# Altium schematic sheet MooresGlobe r3.SchDoc
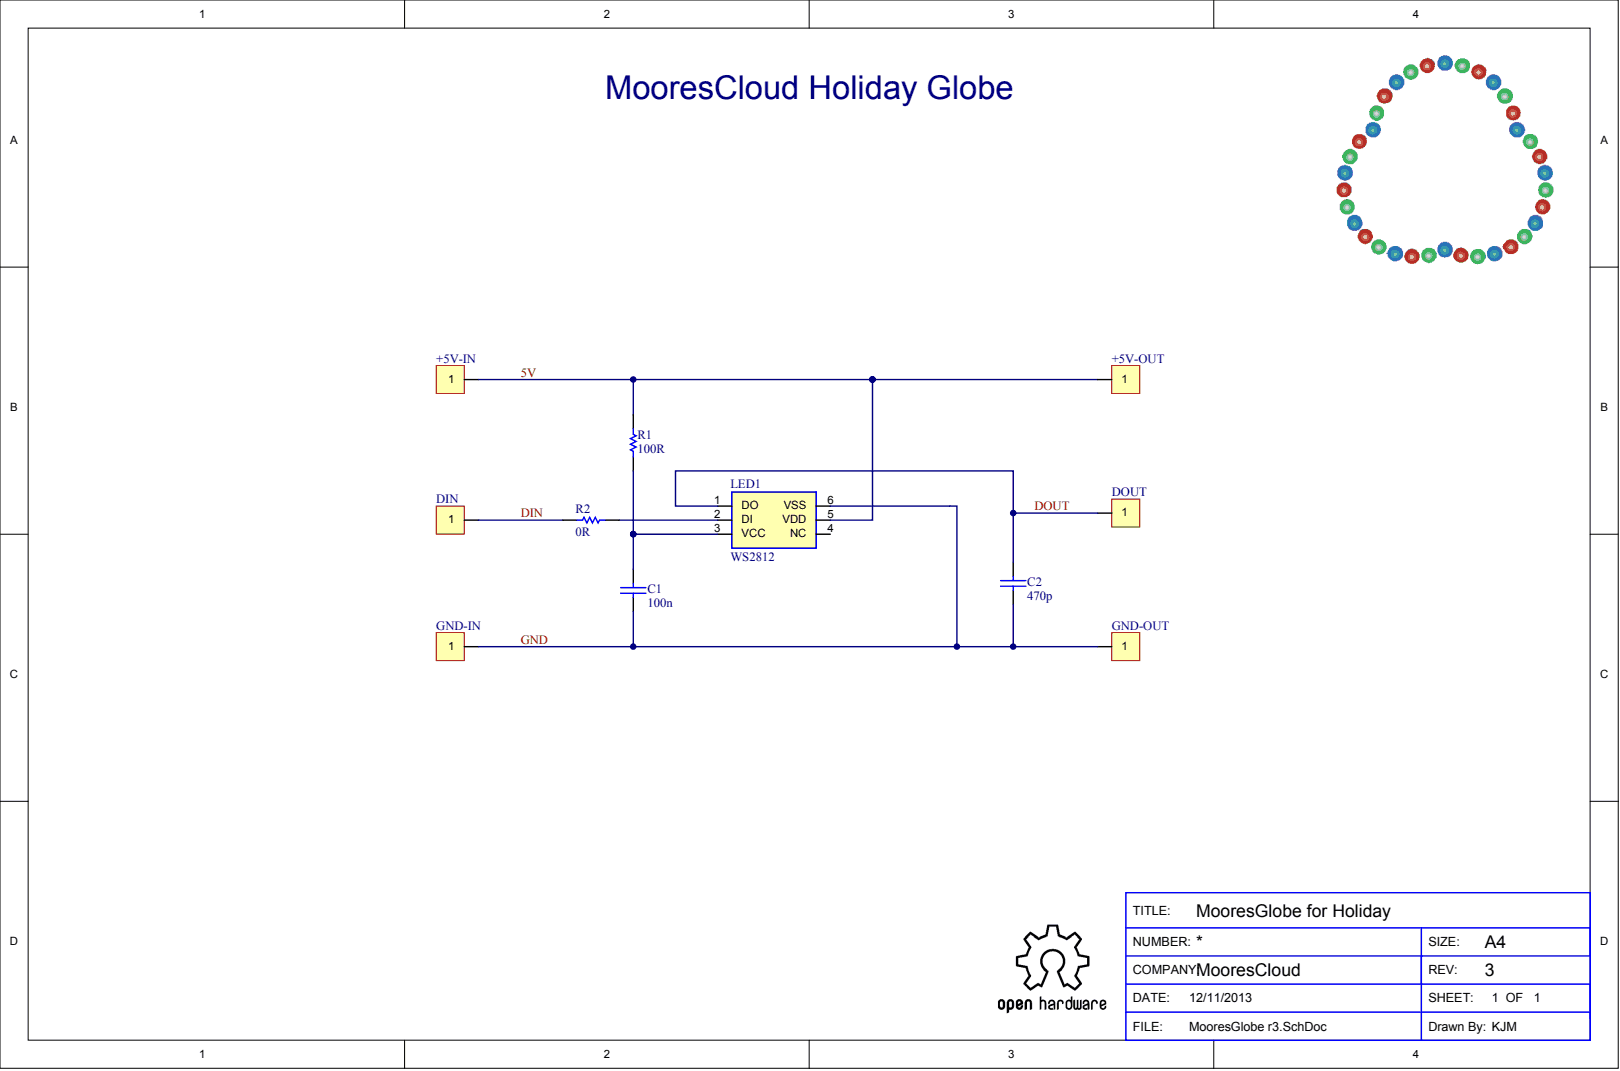
[diagram p0: MooresGlobe r3.SchDoc]

## Parts
+5V-IN  +5V
+5V-OUT  +5V
C1  100n
C2  470p
DIN  DIN
DOUT  DOUT
GND-IN  GND
GND-OUT  GND
LED1  WS2812
R1  100R
R2  0R

## Nets
5V: +5V-IN.1 +5V-OUT.1 LED1.5(VDD) R1.1
DIN: DIN.1 R2.2
DOUT: C2.1 DOUT.1 LED1.1(DO)
GND: C1.2 C2.2 GND-IN.1 GND-OUT.1 LED1.6(VSS)
NetC1_1: C1.1 LED1.3(VCC) R1.2
NetLED1_2: LED1.2(DI) R2.1

Not connected: LED1 4(NC)

## Library data of the parts
C1 = (no symbol name): 1.Manufacturer: Yageo; 4.CatNo: 311-1343-2-ND; 5.Note: Ceramic; 2.MfgNo: CC0603ZRY5V9BB104; 9.UnitCost: 0.00206; 3.Supplier: DigiKey
C2 = (no symbol name): 1.Manufacturer: Yageo; 4.CatNo: 311-1520-2-ND; 5.Note: Ceramic; 2.MfgNo: CC0603JRNPO9BN471; 9.UnitCost: 0.00526; 3.Supplier: DigiKey
LED1 = (no symbol name): 1.Manufacturer: WorldSemi; 9.UnitCost: 0.15; 2.MfgNo: WS2812; 3.Supplier: Shenzhen Worldsemi Technology; 4.CatNo: WS2812
R1 = (no symbol name): 1.Manufacturer: Yageo; 4.CatNo: 311-100GRTR-ND; 2.MfgNo: RC0603JR-07100RL; 9.UnitCost: 0.00092; 3.Supplier: DigiKey
R2 = (no symbol name): 1.Manufacturer: Yageo; 4.CatNo: 311-0.0GRTR-ND; 2.MfgNo: RC0603JR-070RL; 9.UnitCost: 0.00092; 3.Supplier: DigiKey
